# Altium schematic sheet NRF24L01+PA+LAN.SchDoc
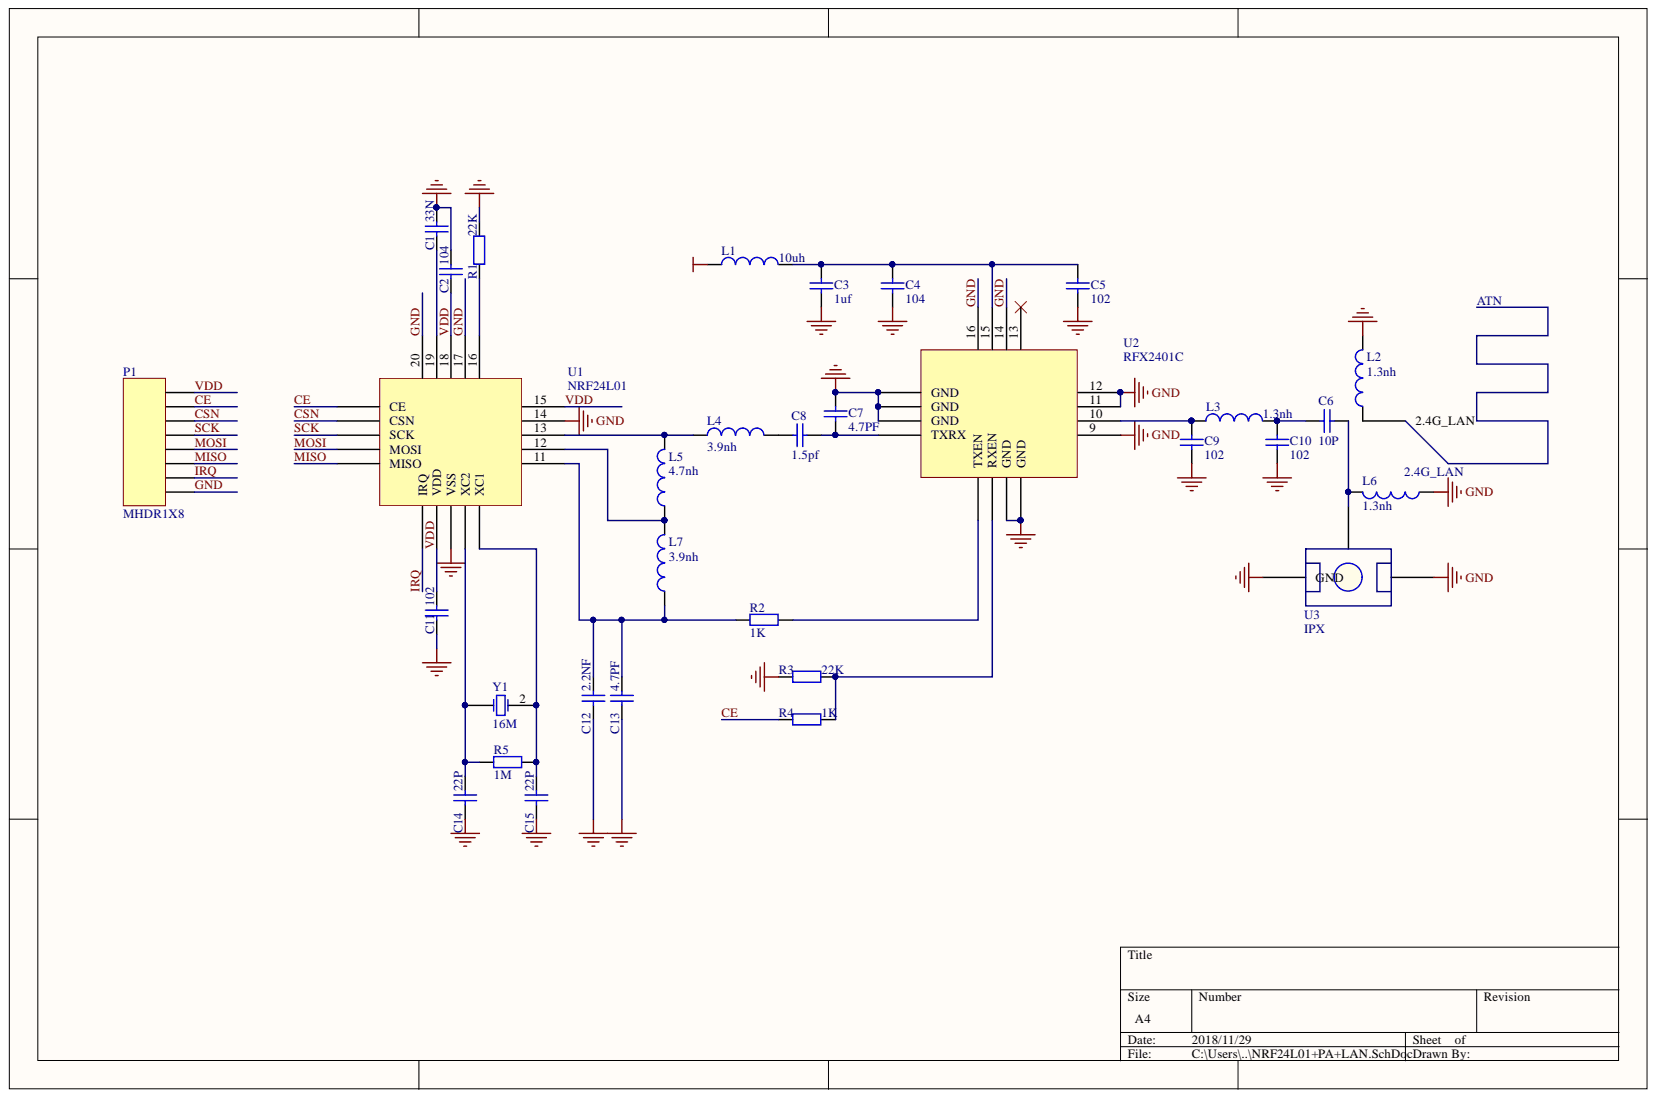
[diagram p0: NRF24L01+PA+LAN.SchDoc]

## Parts
ATN  2.4G_LAN
C1  33N  [C0603]
C2  104  [C0603]
C3  1uf  [C0603]
C4  104  [C0603]
C5  102  [C0603]
C6  10P  [C0603]
C7  4.7PF  [C0603]
C8  1.5pf  [C0603]
C9  102  [C0603]
C10  102  [C0603]
C11  102  [C0603]
C12  2.2NF  [C0603]
C13  4.7PF  [C0603]
C14  22P  [C0603]
C15  22P  [C0603]
L1  10uh  [0402-A]
L2  1.3nh  [0402-A]
L3  1.3nh  [0402-A]
L4  3.9nh  [0402-A]
L5  4.7nh  [0402-A]
L6  1.3nh  [0402-A]
L7  3.9nh  [0402-A]
P1  MHDR1X8  [MHDR1X8]
R1  22K  [AXIAL-0.4]
R2  1K  [AXIAL-0.4]
R3  22K  [AXIAL-0.4]
R4  1K  [AXIAL-0.4]
R5  1M  [AXIAL-0.4]
U1  NRF24L01
U2  RFX2401C
U3  IPX
Y1  16M  [R38]

## Nets
CE: P1.2 R4.2 U1.1(CE)
CSN: P1.3 U1.2(CSN)
GND: C1.2 C2.1 C3.2 C4.2 C5.1 C7.2 C9.1 C10.1 C11.2 C12.2 C13.2 C14.2 C15.2 L2.2 L6.1 P1.8 R1.2 R3.2 U1.8(VSS) U1.14 U1.17 U1.20 U2.1(GND) U2.2(GND) U2.3(GND) U2.7(GND) U2.8(GND) U2.9 U2.11 U2.12 U2.14 U2.16 U3.2 U3.3
IRQ: P1.7 U1.6(IRQ)
MISO: P1.6 U1.5(MISO)
MOSI: P1.5 U1.4(MOSI)
SCK: P1.4 U1.3(SCK)
VDD: C2.2 C11.1 L1.1 P1.1 U1.7(VDD) U1.15 U1.18
NetATN_1: ATN.1 L2.1
NetC1_1: C1.1 U1.19
NetC3_1: C3.1 C4.1 C5.2 L1.2 U2.15
NetC6_1: C6.1 L6.2 U3.1
NetC6_2: C6.2 C10.2 L3.2
NetC7_1: C7.1 C8.2 U2.4(TXRX)
NetC8_1: C8.1 L4.2
NetC9_2: C9.2 L3.1 U2.10
NetC12_1: C12.1 C13.1 L7.1 R2.2 U1.11
NetC14_1: C14.1 R5.2 U1.9(XC2) Y1.1
NetC15_1: C15.1 R5.1 U1.10(XC1) Y1.2
NetL4_1: L4.1 L5.2 U1.13
NetL5_1: L5.1 L7.2 U1.12
NetR1_1: R1.1 U1.16
NetR2_1: R2.1 U2.5(TXEN)
NetR3_1: R3.1 R4.1 U2.6(RXEN)

Not connected: U2 13

## Library data of the parts
ATN = Component_1: Component Kind: Standard; Library Name: 2.4G_LAN.SchLib
C1-C15 = Cap: Capacitor; Component Kind: Standard; LatestRevisionDate: 17-Jul-2002; LatestRevisionNote: Re-released for DXP Platform.; Library Name: Miscellaneous Devices.IntLib; PackageDescription: Radial Cap, Thru-Hole; 2 Leads; 0.3 in Pin Spacing; PackageReference: RAD-0.3; Published: 8-Jun-2000; Signal Integrity: Cap; Simulation: CAP; Publisher: Altium Limited
L1-L7 = Inductor: Code_IEC: 1005; Code_JEITA: 0402; Component Kind: Standard; Description: Inductor; LatestRevisionDate: 29-May-2009; LatestRevisionNote: IPC-7351 Footprint Added.; Library Name: Miscellaneous Devices.IntLib; PackageDescription: Chip Inductor; PackageReference: 0402-A; Published: 8-Jun-2000; Signal Integrity: Inductor; Simulation: INDUCTOR; Publisher: Altium Limited
P1 = MHDR1X8: Header, 8-Pin; Component Kind: Standard; LatestRevisionDate: 17-Jul-2002; LatestRevisionNote: Re-released for DXP Platform.; Library Name: Miscellaneous Connectors.IntLib; Signal Integrity: Connector; Publisher: Altium Limited
R1-R5 = Res2: Resistor; Component Kind: Standard; LatestRevisionDate: 17-Jul-2002; LatestRevisionNote: Re-released for DXP Platform.; Library Name: Miscellaneous Devices.IntLib; PackageDescription: Axial Device, Thru-Hole; 2 Leads; 0.4 in Pin Spacing; PackageReference: AXIAL-0.4; Published: 8-Jun-2000; Signal Integrity: Res; Simulation: RESISTOR; Publisher: Altium Limited
Y1 = XTAL: Crystal Oscillator; Component Kind: Standard; LatestRevisionDate: 17-Jul-2002; LatestRevisionNote: Re-released for DXP Platform.; Library Name: Miscellaneous Devices.IntLib; PackageDescription: Crystal, Thru-Hole; 2 Leads; Body 3.1 x 8.2 mm (Dia.xH); PackageReference: R38; Published: 8-Jun-2000; Simulation: XTAL; Publisher: Altium Limited
U1 = NRF24L01: Component Kind: Standard; Library Name: NRF24L01.SchLib
U2 = RFX2401C: Component Kind: Standard; Library Name: RFX2401C.SchLib
U3 = IPX: Component Kind: Standard; Library Name: IPX.SchLib
